# Altium schematic sheet 02-AD9546_StrapsXtal.SchDoc
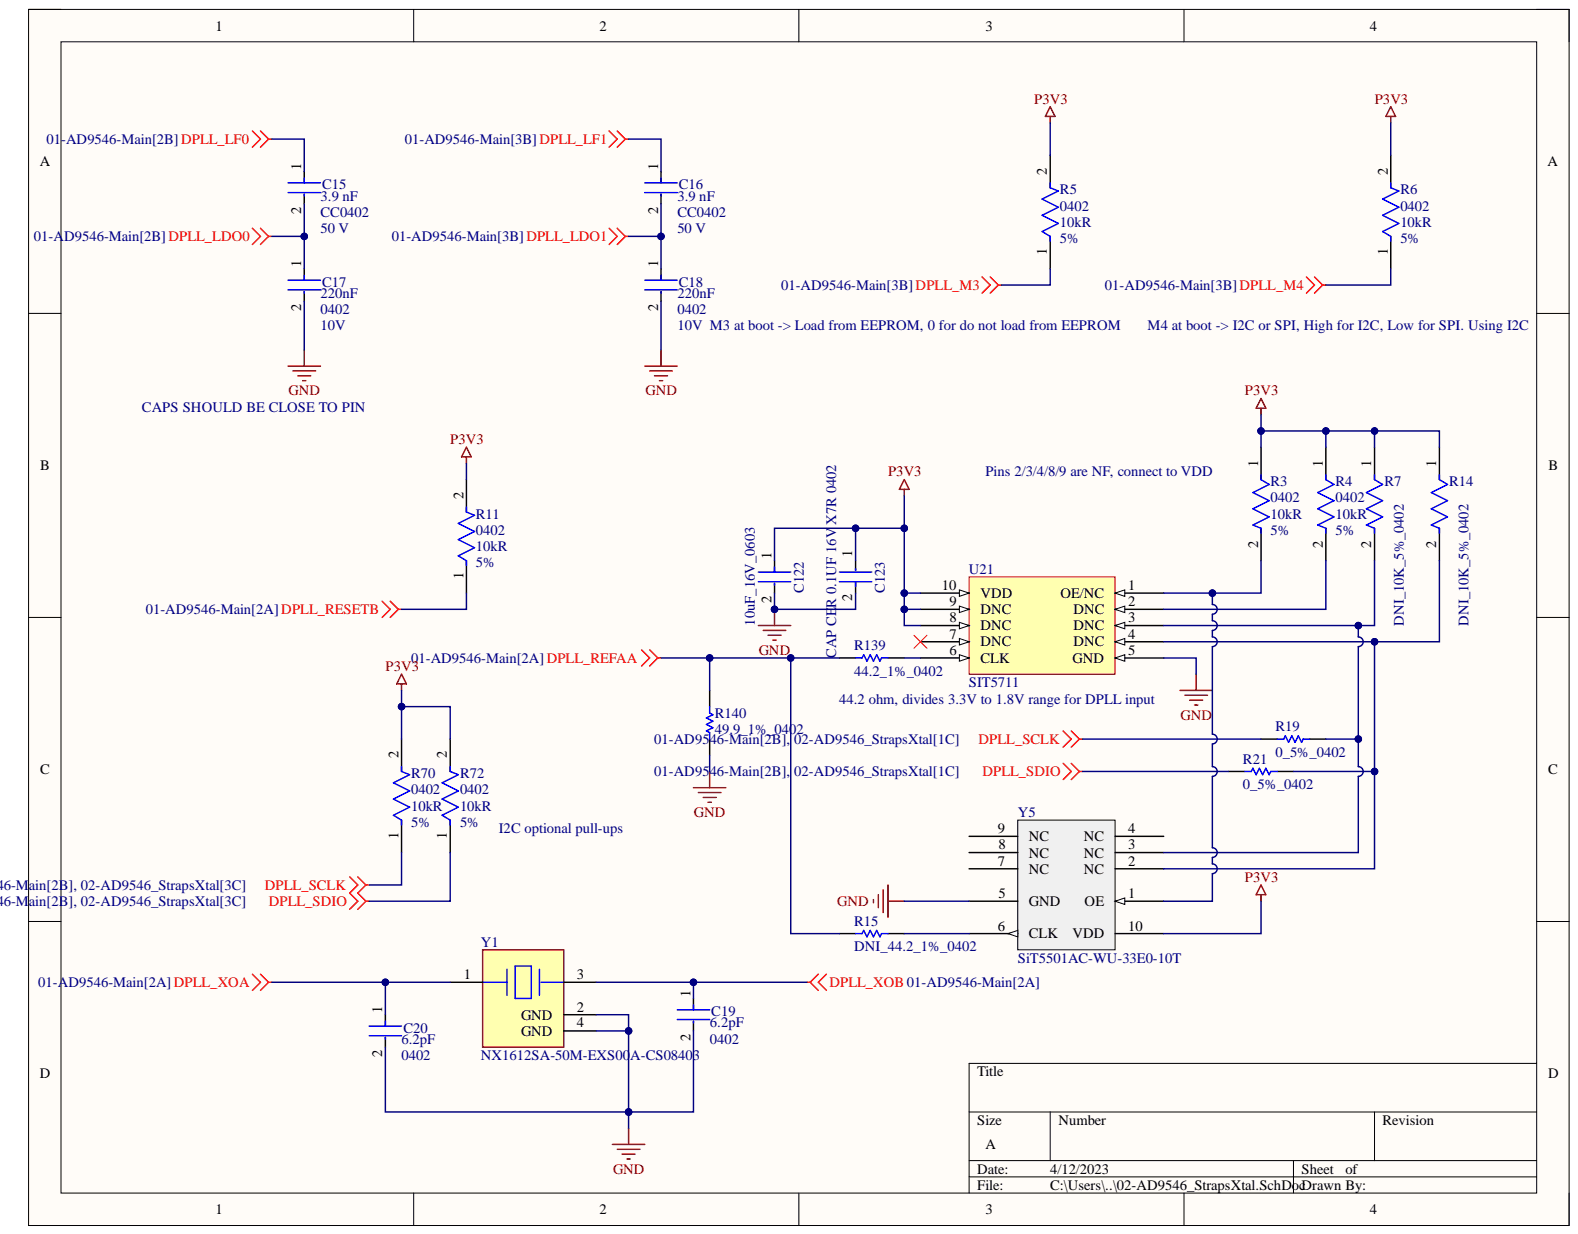
[diagram p0: 02-AD9546_StrapsXtal.SchDoc]

## Parts
C15  value 3.9 nF  Capacitance: 3.9 nF  Package: CC0402  Voltage Rating: 50 V  [FP-CC0402-MFG]  (hidden comment: CC0402KRX7R9BB392)
C16  value 3.9 nF  Capacitance: 3.9 nF  Package: CC0402  Voltage Rating: 50 V  [FP-CC0402-MFG]  (hidden comment: CC0402KRX7R9BB392)
C17  Capacitance: 220nF  Case Code (Imperial): 0402  Voltage: 10V  [FP-GRM155-0_05-IPC_A]  (hidden comment: GRM155R61A224KE19D)
C18  Capacitance: 220nF  Case Code (Imperial): 0402  Voltage: 10V  [FP-GRM155-0_05-IPC_A]  (hidden comment: GRM155R61A224KE19D)
C19  Capacitance: 6.2pF  Case Code (Imperial): 0402  [FP-CL468-IPC_C]  (hidden comment: CL05C6R2CB5NNNC)
C20  Capacitance: 6.2pF  Case Code (Imperial): 0402  [FP-CL468-IPC_C]  (hidden comment: CL05C6R2CB5NNNC)
C122  10uF_16V_0603  [FP-C1608-MFG]
C123  GRM155R71C104KA88D  [FP-GRM15-MFG]  (value not drawn)
R3  Case Code (Imperial): 0402  Resistance: 10kR  Tolerance: 5%  [FP-CRCW0402-e3-IPC_B]  (hidden comment: CRCW040210K0JNED)
R4  Case Code (Imperial): 0402  Resistance: 10kR  Tolerance: 5%  [FP-CRCW0402-e3-IPC_B]  (hidden comment: CRCW040210K0JNED)
R5  Case Code (Imperial): 0402  Resistance: 10kR  Tolerance: 5%  [FP-CRCW0402-e3-IPC_B]  (hidden comment: CRCW040210K0JNED)
R6  Case Code (Imperial): 0402  Resistance: 10kR  Tolerance: 5%  [FP-CRCW0402-e3-IPC_B]  (hidden comment: CRCW040210K0JNED)
R7  DNI_10K_5%_0402  Case Code (Imperial): 0402  Resistance: 10kR  [FP-CRCW0402-e3-IPC_B]  (no BOM)
R11  Case Code (Imperial): 0402  Resistance: 10kR  Tolerance: 5%  [FP-CRCW0402-e3-IPC_B]  (hidden comment: CRCW040210K0JNED)
R14  DNI_10K_5%_0402  [FP-CRCW0402-e3-IPC_B]  (no BOM)
R15  DNI_44.2_1%_0402  [RESC0402(1005)_M]  (no BOM)
R19  0_5%_0402  [RESC1005X40X25NL05T10]
R21  0_5%_0402  [RESC1005X40X25NL05T10]
R70  Case Code (Imperial): 0402  Resistance: 10kR  Tolerance: 5%  [FP-CRCW0402-e3-IPC_B]  (hidden comment: CRCW040210K0JNED)
R72  Case Code (Imperial): 0402  Resistance: 10kR  Tolerance: 5%  [FP-CRCW0402-e3-IPC_B]  (hidden comment: CRCW040210K0JNED)
R139  44.2_1%_0402  [RESC0402(1005)_M]
R140  49.9_1%_0402  [RESC1005X40X25NL05T05]
U21  SIT5711  [SIT5711]  (no BOM)
Y1  NX1612SA-50M-EXS00A-CS08403  [FP-NX1612SA-MFG]
Y5  Manufacturer Part Number: SiT5501AC-WU-33E0-10T  [SITIME_SPL-086-CQFN-010-C07050_REV_DRAFT]  (hidden comment: SiTime_SiT5501AC-WU-33E0-10T)  (no BOM)

## Nets
DPLL_LDO0: C15.2 C17.1
DPLL_LDO1: C16.2 C18.1
DPLL_LF0: C15.1
DPLL_LF1: C16.1
DPLL_M3: R5.1
DPLL_M4: R6.1
DPLL_REFAA: R15.1 R139.1 R140.2
DPLL_RESETB: R11.1
DPLL_SCLK: R19.1 R70.1
DPLL_SDIO: R21.1 R72.1
DPLL_XOA: C20.1 Y1.1
DPLL_XOB: C19.1 Y1.3
GND: C17.2 C18.2 C19.2 C20.2 C122.2 C123.2 R140.1 U21.5(GND) Y1.2(GND) Y1.4(GND) Y5.5(GND)
P3V3: C122.1 C123.1 R3.1 R4.1 R5.2 R6.2 R7.1 R11.2 R14.1 R70.2 R72.2 U21.8(DNC) U21.9(DNC) U21.10(VDD) Y5.10(VDD)
NetR3_2: R3.2 U21.1(OE/NC) Y5.1(OE)
NetR4_2: R4.2 U21.2(DNC)
NetR7_2: R7.2 R19.2 U21.3(DNC) Y5.3(NC)
NetR14_2: R14.2 R21.2 U21.4(DNC) Y5.2(NC)
NetR15_2: R15.2 Y5.6(CLK)
NetR139_2: R139.2 U21.6(CLK)

Not connected: U21 7(DNC); Y5 4(NC) 7(NC) 8(NC) 9(NC)

## Library data of the parts
C15 C16 = CMP-03422-000890-1: CAP CER 3900PF 50V X7R 0402; Manufacturer: YAGEO; Automotive Grade: NA; Material: Ceramic; Temperature Coefficient: 30ppm/°C; Tolerance: 0.1; Automotive: No; Category: Cap; Component Kind: Standard; ComponentLink2Description: Datasheet; ComponentLink2URL: http://www.yageo.com/documents/recent/UPY-GP_NP0_16V-to-50V_16.pdf; Device Class L1: Passive Components; Device Class L2: Capacitors; Device Class L3: Ceramic Capacitors; Digikey Description: CAP CER 3900PF 50V X7R 0402; Footprint Url: http://www.yageo.com/exep/pages/download/literatures/UPY-C_GEN_24.pdf; Height: 0.55mm; Ipc Land Pattern Name: CAPC10050X50; Lead Free: Yes; Library Name: Altium Content Vault; Manufacturer Part Number: CC0402KRX7R9BB392; Mouser Description: Multilayer Ceramic Capacitors MLCC - SMD/SMT 3.9nF 50V X7R 10%; Octopart: https://octopart.com/cc0402krx7r9bb392-yageo-9291741; RoHS: TRUE; Temperature: -55°C to +125°C; Temperature Characteristic: X7R; Temperature Range High: +125°C; Temperature Range Low: -55°C
C17 C18 = CMP-2008-04561-5: CAP CER 0.22UF 10V X5R 0402; Dielectric Material: Ceramic; Insulation Resistance: 10GR; Tolerance: 10%; Case Code (Metric): 1005; Case/Package: 0402; Component Kind: Standard; Depth: 0.5mm; Dielectric: X5R; Height: 0.5mm; Lead Free: Lead Free; Length: 1mm; Library Name: Altium Content Vault; Material: Ceramic; Max Operating Temperature: 85°C; Min Operating Temperature: -55°C; Mount: Surface Mount; Number of Pins: 2; Package Quantity: 1; Packaging: Tape and Reel; Radiation Hardening: No; REACH SVHC: No SVHC; RoHS Compliant: Yes; Series: GRM; Termination: SMD/SMT; Thickness: 0.022inch; Voltage Rating: 10V; Voltage Rating (DC): 10V; Width: 0.5mm
C19 C20 = CMP-13271-000420-1: Cap Ceramic 6.2pF 50V C0G ±0.25pF SMD 0402 +125°C Paper T/R; Case Code (Metric): 1005; Case/Package: 0402; Component Kind: Standard; Depth: 0.5mm; Dielectric: C0G; Height: 0.5mm; Length: 1mm; Library Name: Altium Content Vault; Max Operating Temperature: 125°C; Min Operating Temperature: -55°C; Mount: Surface Mount; Packaging: Tape and Reel; Radiation Hardening: No; Thickness: 0.022inch; Voltage Rating: 50V; Voltage Rating (DC): 50V; Width: 0.02inch
C122 = CMP-08246-000019-1: CAP CER 10UF 16V X5R 0603; Manufacturer: TDK Corporation; Manufacturer Part Number: C1608X5R1C106M080AB; Material: Ceramic; Temperature Coefficient: 15%; Tolerance: 20%; Automotive: No; Capacitance: 10uF; Category: Cap; Component Kind: Standard; ComponentLink2Description: Datasheet; ComponentLink2URL: https://upverter.com/datasheet/6fbac97fd59b4a24a005814037d2a36a27db40e9.pdf; Device Class L1: Passive Components; Device Class L2: Capacitors; Device Class L3: Ceramic Capacitors; Digikey Description: CAP CER 10UF 16V X5R 0603; Height: 0.9mm; Ipc Land Pattern Name: CAPC16080X80; Lead Free: Yes; Library Name: Altium Content Vault; Mouser Description: Multilayer Ceramic Capacitors MLCC - SMD/SMT 0603 10uF 16volts X5R +/-20% GP; Octopart: https://octopart.com/c1608x5r1c106m080ab-tdk-26013480; Package: C1608; RoHS: TRUE; Temperature: -55°C to +85°C; Temperature Characteristic: X5R; Temperature Range High: +85°C; Temperature Range Low: -55°C; Value: 10uF; Voltage Rating: 16V
C123 = CMP-2100-03641-2: CAP CER 0.1UF 16V X7R 0402; Manufacturer: Murata; Capacitance: 0.10μF; Material: Ceramic; Standoff Height: 0mm; Tolerance: 10%; Value: 0.10μF; Voltage Rating: 16Vdc; Category: Cap; Component Kind: Standard; ComponentLink2Description: Datasheet; ComponentLink2URL: https://search.murata.co.jp/Ceramy/image/img/A01X/G101/ENG/GRM155R71H471KA01-01A.pdf; Device Class L1: Passive Components; Device Class L2: Capacitors; Device Class L3: Ceramic Capacitors; Digikey Description: CAP CER 0.1UF 16V X7R 0402; Height: 0.55mm; Ipc Land Pattern Name: CAPC10050X219; Lead Free: yes; Library Name: Altium Content Vault; Manufacturer Part Number: GRM155R71C104KA88D; Mouser Description: 0402 0.1 uF 16V ±10 % Tolerance X7R SMT Multilayer Ceramic Capacitor; Octopart: https://octopart.com/grm155r71c104ka88d-murata-196880; Package: GRM15; RoHS: TRUE; Temperature: -55°C to +125°C; Temperature Characteristic: X7R; Temperature Range High: +125°C; Temperature Range Low: -55°C
R3-R7 R11 R70 R72 = CMP-2002-08136-3: RES Thick Film, 10kΩ, 5%, 0.063W, 200ppm/°C, 0402; Max Power Dissipation: 63mW; Voltage Rating: 50V; Case Code (Metric): 1005; Case/Package: 0402; Composition: Thick Film; Contact Plating: Tin; Depth: 0.5mm; Features: Automotive AEC-Q200; Height: 0.016inch; Length: 1mm; Library Name: Altium Content Vault; Max Operating Temperature: 155°C; Military Standard: Not; Min Operating Temperature: -55°C; Mount: Surface Mount; Number of Terminations: 2; Package Quantity: 1; Packaging: Tape and Reel; Power Rating: 63mW; Radiation Hardening: No; Temperature Coefficient: 200ppm/°C; Termination: SMD/SMT; Weight: 2.3E-05oz; Width: 0.5mm
  R3-R6 R11 R70 R72: Component Kind: Standard
  R7: Tolerance: 5%
R14 = CMP-2002-08136-3: RES Thick Film, 10kΩ, 5%, 0.063W, 200ppm/°C, 0402; Max Power Dissipation: 63mW; Resistance: 10kR; Voltage Rating: 50V; Case Code (Imperial): 0402; Case Code (Metric): 1005; Case/Package: 0402; Composition: Thick Film; Contact Plating: Tin; Depth: 0.5mm; Features: Automotive AEC-Q200; Height: 0.016inch; Length: 1mm; Library Name: Altium Content Vault; Max Operating Temperature: 155°C; Military Standard: Not; Min Operating Temperature: -55°C; Mount: Surface Mount; Number of Terminations: 2; Package Quantity: 1; Packaging: Tape and Reel; Power Rating: 63mW; Radiation Hardening: No; Temperature Coefficient: 200ppm/°C; Termination: SMD/SMT; Tolerance: 5%; Weight: 2.3E-05oz; Width: 0.5mm
R15 R139 = CMP-1011-00357-1: 44R2 0.063W 1% 0402 (1005 Metric)  SMD; Case-EIA: 0402; Case-Metric: 1005; Value: 44.20 Ohm; Library Name: Altium Content Vault; Power: 0.063 W; Technology: SMT; Tolerance: 1 %
  R139: Component Kind: Standard
R19 R21 = CMP-1011-00001-2: Manufacturer: Yageo / Phycomp; Part Number: RC0402JR-070RL; Component Kind: Standard; Library Name: Altium Content Vault
R140 = CMP-2002-00969-1: Manufacturer: Panasonic; Part Number: ERJ-2RKF49R9X; Component Kind: Standard; Description: 49.9_1%_0402; Library Name: Altium Content Vault
Y1 = CMP-200220-000009-1: CRYSTAL 50MHZ 8PF SMD; ESR (Equivalent Series Resistance): 80R; Frequency Stability: 15ppm; Frequency Tolerance: 10ppm; Case/Package: SMD/SMT; Component Kind: Standard; Frequency: 50MHz; Library Name: Altium Content Vault; Load Capacitance: 8pF; Max Operating Temperature: 85°C; Min Operating Temperature: -30°C; Mount: Surface Mount; Packaging: Cut Tape
Y5 = CMP-OSC-00029-2: OSC, MEMS, STRATUM 3E, 10.000MHZ, 10PPB STAB, LVCMOS, 3.3V, O/P EN, 7.0X5.0X2.2MM, CQFN-10; Internal Part Number: 820-00386-00; Manufacturer: SiTime; Frequency: 10 MHz; Case/Package: 10 Lead QFN; Category: Oscillators; Frequency Stability: ±10 ppb; Height: 2.20mm (max); Max Operating Temperature: 70°C; Min Operating Temperature: -20°C; Mounting Type: Surface Mount; Output: LVCMOS; RoHS: Yes; Type: OCXO; Voltage - Supply: 3.3 V; Library Name: Meta RLR Altium Enterprise Server
U21 = SIT5711: Library Name: TimeDrive_Lib.SchLib
